# Altium schematic sheet LIDO-HW.400.AccessoryInterfaces.SchDoc(LIDO-HW.400.AccessoryInterfaces)
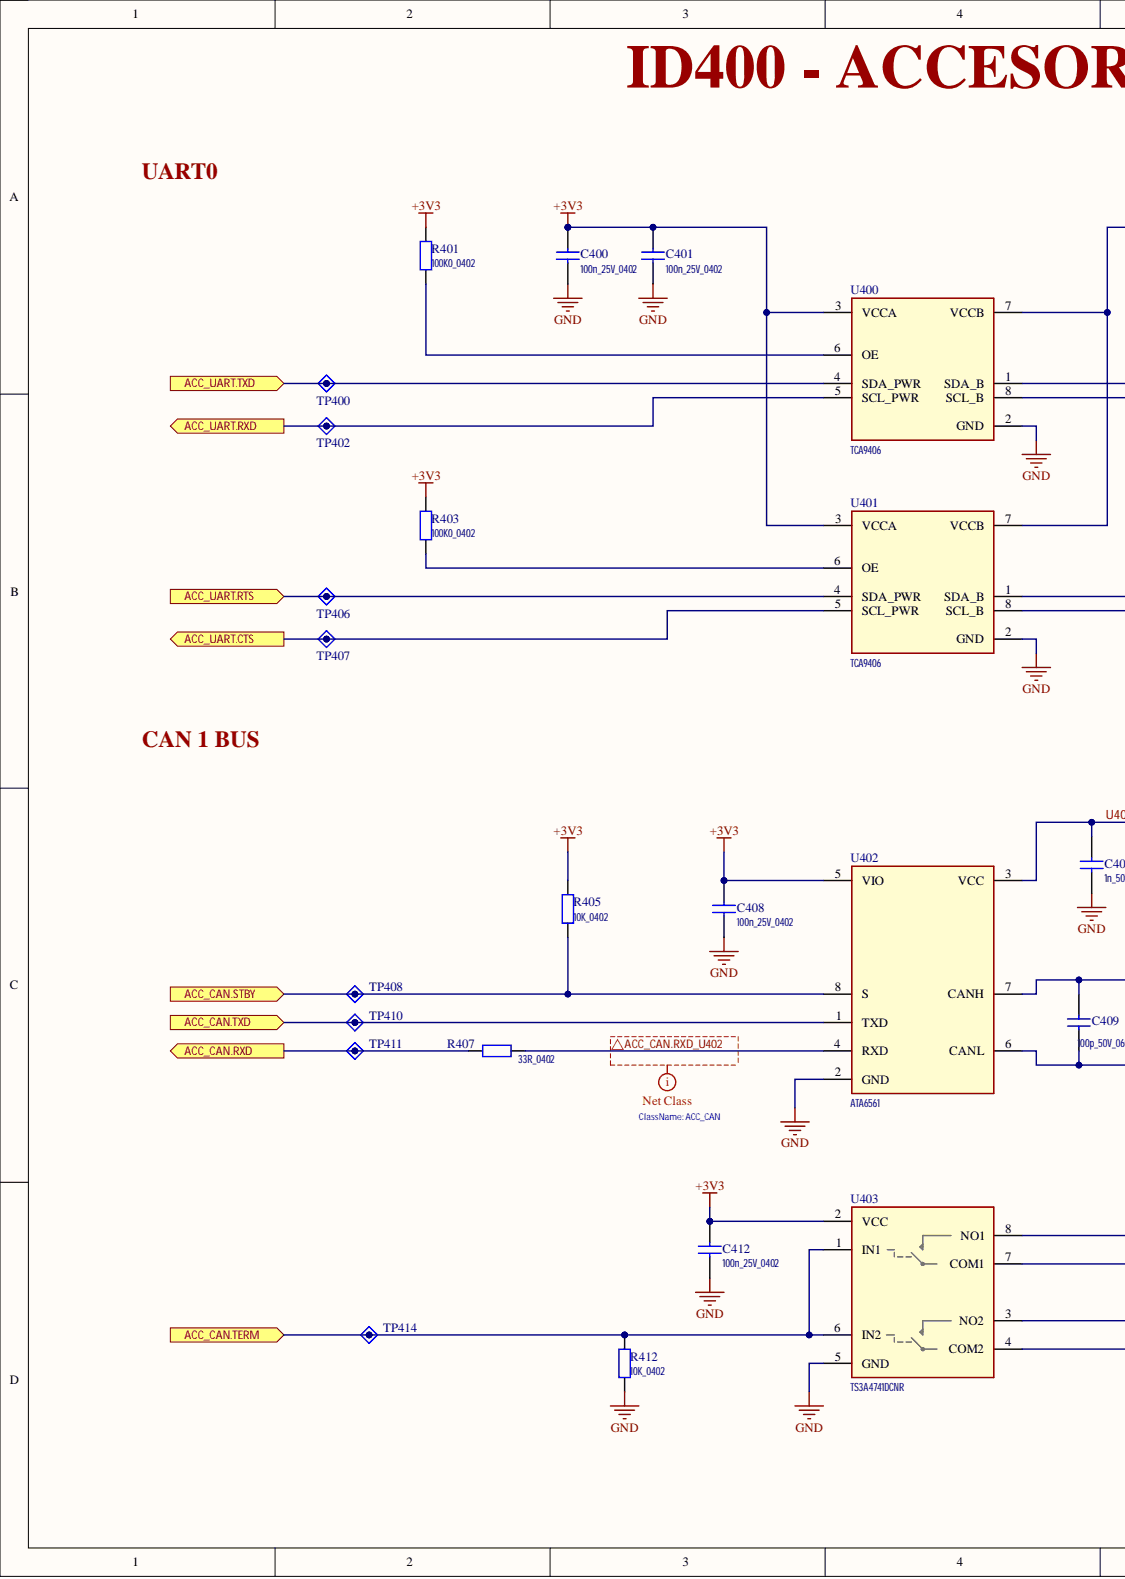
[diagram p0: LIDO-HW.400.AccessoryInterfaces.SchDoc, left]
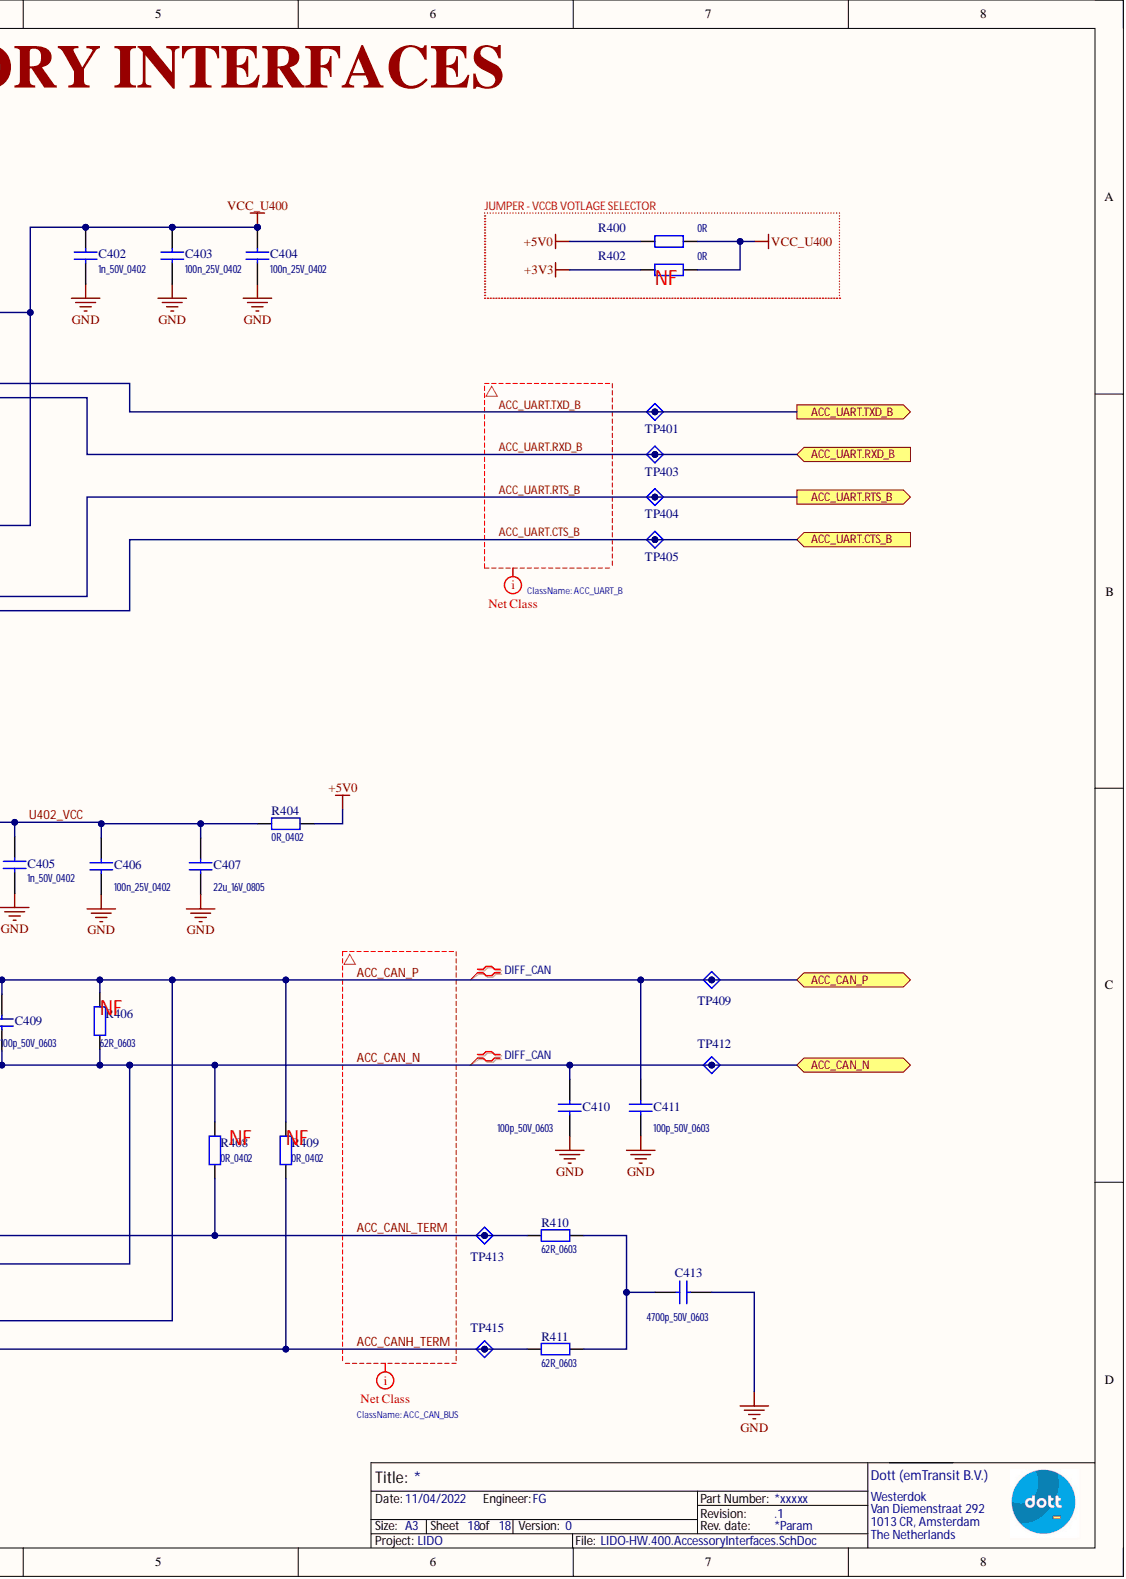
[diagram p1: LIDO-HW.400.AccessoryInterfaces.SchDoc, right]

## Parts
C400  100n_25V_0402
C401  100n_25V_0402
C402  1n_50V_0402
C403  100n_25V_0402
C404  100n_25V_0402
C405  1n_50V_0402
C406  100n_25V_0402
C407  22u_16V_0805
C408  100n_25V_0402
C409  100p_50V_0603
C410  100p_50V_0603
C411  100p_50V_0603
C412  100n_25V_0402
C413  4700p_50V_0603
R400  0R
R401  100K0_0402
R402  0R
R403  100K0_0402
R404  0R_0402
R405  10K_0402
R406  62R_0603
R407  33R_0402
R408  0R_0402
R409  0R_0402
R410  62R_0603
R411  62R_0603
R412  10K_0402
TP400  TestPoint_PWR  (value not drawn)  (no BOM)
TP401  TestPoint_PWR  (value not drawn)  (no BOM)
TP402  TestPoint_PWR  (value not drawn)  (no BOM)
TP403  TestPoint_PWR  (value not drawn)  (no BOM)
TP404  TestPoint_PWR  (value not drawn)  (no BOM)
TP405  TestPoint_PWR  (value not drawn)  (no BOM)
TP406  TestPoint_PWR  (value not drawn)  (no BOM)
TP407  TestPoint_PWR  (value not drawn)  (no BOM)
TP408  TestPoint_PWR  (value not drawn)  (no BOM)
TP409  TestPoint_PWR  (value not drawn)  (no BOM)
TP410  TestPoint_PWR  (value not drawn)  (no BOM)
TP411  TestPoint_PWR  (value not drawn)  (no BOM)
TP412  TestPoint_PWR  (value not drawn)  (no BOM)
TP413  TestPoint_PWR  (value not drawn)  (no BOM)
TP414  TestPoint_PWR  (value not drawn)  (no BOM)
TP415  TestPoint_PWR  (value not drawn)  (no BOM)
U400  TCA9406
U401  TCA9406
U402  ATA6561  [SOIC8P127_490X600X175L83X42N]
U403  TS3A4741DCNR  [TSOP65P280X145-8N]

## Ports
ACC_CAN.RXD, ACC_CAN.STBY, ACC_CAN.TERM, ACC_CAN.TXD, ACC_CAN_N, ACC_CAN_P, ACC_UART.CTS, ACC_UART.CTS_B, ACC_UART.RTS, ACC_UART.RTS_B, ACC_UART.RXD, ACC_UART.RXD_B, ACC_UART.TXD, ACC_UART.TXD_B

## Nets
+3V3: C400.1 C401.1 C408.1 C412.1 R401.1 R402.1 R403.1 R405.1 U400.3(VCCA) U401.3(VCCA) U402.5(VIO) U403.2(VCC)
+5V0: R400.1 R404.1
GND: C400.2 C401.2 C402.2 C403.2 C404.2 C405.2 C406.2 C407.2 C408.2 C410.2 C411.1 C412.2 C413.2 R412.2 U400.2(GND) U401.2(GND) U402.2(GND) U403.5(GND)
VCC_U400: C402.1 C403.1 C404.1 R400.2 R402.2 U400.7(VCCB) U401.7(VCCB)
ACC_CAN.RXD: R407.2 TP411.1 ; port ACC_CAN.RXD
ACC_CAN.RXD_U402: R407.1 U402.4(RXD)
ACC_CAN.STBY: R405.2 TP410.1 U402.8(S) ; port ACC_CAN.STBY
ACC_CAN.TERM: R412.1 TP414.1 U403.1(IN1) U403.6(IN2) ; port ACC_CAN.TERM
ACC_CAN.TXD: TP408.1 U402.1(TXD) ; port ACC_CAN.TXD
ACC_CAN_N: C409.2 C410.1 R406.2 R408.1 TP412.1 U402.6(CANL) U403.7(COM1) ; port ACC_CAN_N
ACC_CAN_P: C409.1 C411.2 R406.1 R409.1 TP409.1 U402.7(CANH) U403.3(NO2) ; port ACC_CAN_P
ACC_CANH_TERM: R409.2 R411.2 TP415.1 U403.4(COM2)
ACC_CANL_TERM: R408.2 R410.2 TP413.1 U403.8(NO1)
ACC_UART.CTS: TP407.1 U401.5(SCL_PWR) ; port ACC_UART.CTS
ACC_UART.CTS_B: TP405.1 U401.8(SCL_B) ; port ACC_UART.CTS_B
ACC_UART.RTS: TP406.1 U401.4(SDA_PWR) ; port ACC_UART.RTS
ACC_UART.RTS_B: TP404.1 U401.1(SDA_B) ; port ACC_UART.RTS_B
ACC_UART.RXD: TP402.1 U400.5(SCL_PWR) ; port ACC_UART.RXD
ACC_UART.RXD_B: TP403.1 U400.8(SCL_B) ; port ACC_UART.RXD_B
ACC_UART.TXD: TP400.1 U400.4(SDA_PWR) ; port ACC_UART.TXD
ACC_UART.TXD_B: TP401.1 U400.1(SDA_B) ; port ACC_UART.TXD_B
U402_VCC: C405.1 C406.1 C407.1 R404.2 U402.3(VCC)
NetC413_1: C413.1 R410.1 R411.1
NetR401_2: R401.2 U400.6(OE)
NetR403_2: R403.2 U401.6(OE)

## Library data of the parts
C400-C413 = Ceramic: Ceramic CAP Symbol; Component Kind: Standard; Library Name: 01_Capacitors.SchLib
R400-R412 = Resistor: Resistor Symbol; Component Kind: Standard; Library Name: 02_Resistors.SchLib
TP400-TP415 = TestPoint_A: Test Point Symbol verion A; 00_Name: TP_XXmm; 01_Diameter: 1mm; Library Name: 31_MFG_Elements.SchLib
U400 U401 = TCA9406_A: 2-Bit Bidirectional 1-MHz, I  2C Bus and SMBus Voltage-Level Translator With 8-kV HBM ESD; Component Kind: Standard; Library Name: 15_IC_Logic.SchLib
U402 = ATA6561_A: IC TRANSCEIVER 1/1 8SO; Manufacturer: Microchip Technology; Manufacturer Part Number: ATA6561-GAQW; Designer: Matt Drazenovich; Ratings: RoHS; Reviewed: Dekimo_07-07-2020; Case/Package: 8-SOIC; Component Kind: Standard; ComponentType: IC; Design Date: 22-11-2019; Library Name: 15_IC_Interfaces.SchLib; Max Operating Temperature: 150°C; Min Operating Temperature: -40°C; Value: ATA6561
U403 = TS3A4741D_A: 2 Circuit IC Switch 1:1 900mOhm SOT-23-8; Manufacturer: Texas Instruments; Designer: Matt Drazenovich; Ratings: RoHS; Reviewed: Not Footprint; Case/Package: SOT-23-8; Component Kind: Standard; ComponentType: IC; Design Date: 09-09-2021; Library Name: 15_IC_Interfaces.SchLib; Manufacturer Part Number: TS3A4741DCNR; Max Operating Temperature: 85°C; Min Operating Temperature: -40°C; Value: TS3A4741DCNR
